# Altium schematic sheet Sheet6.SchDoc
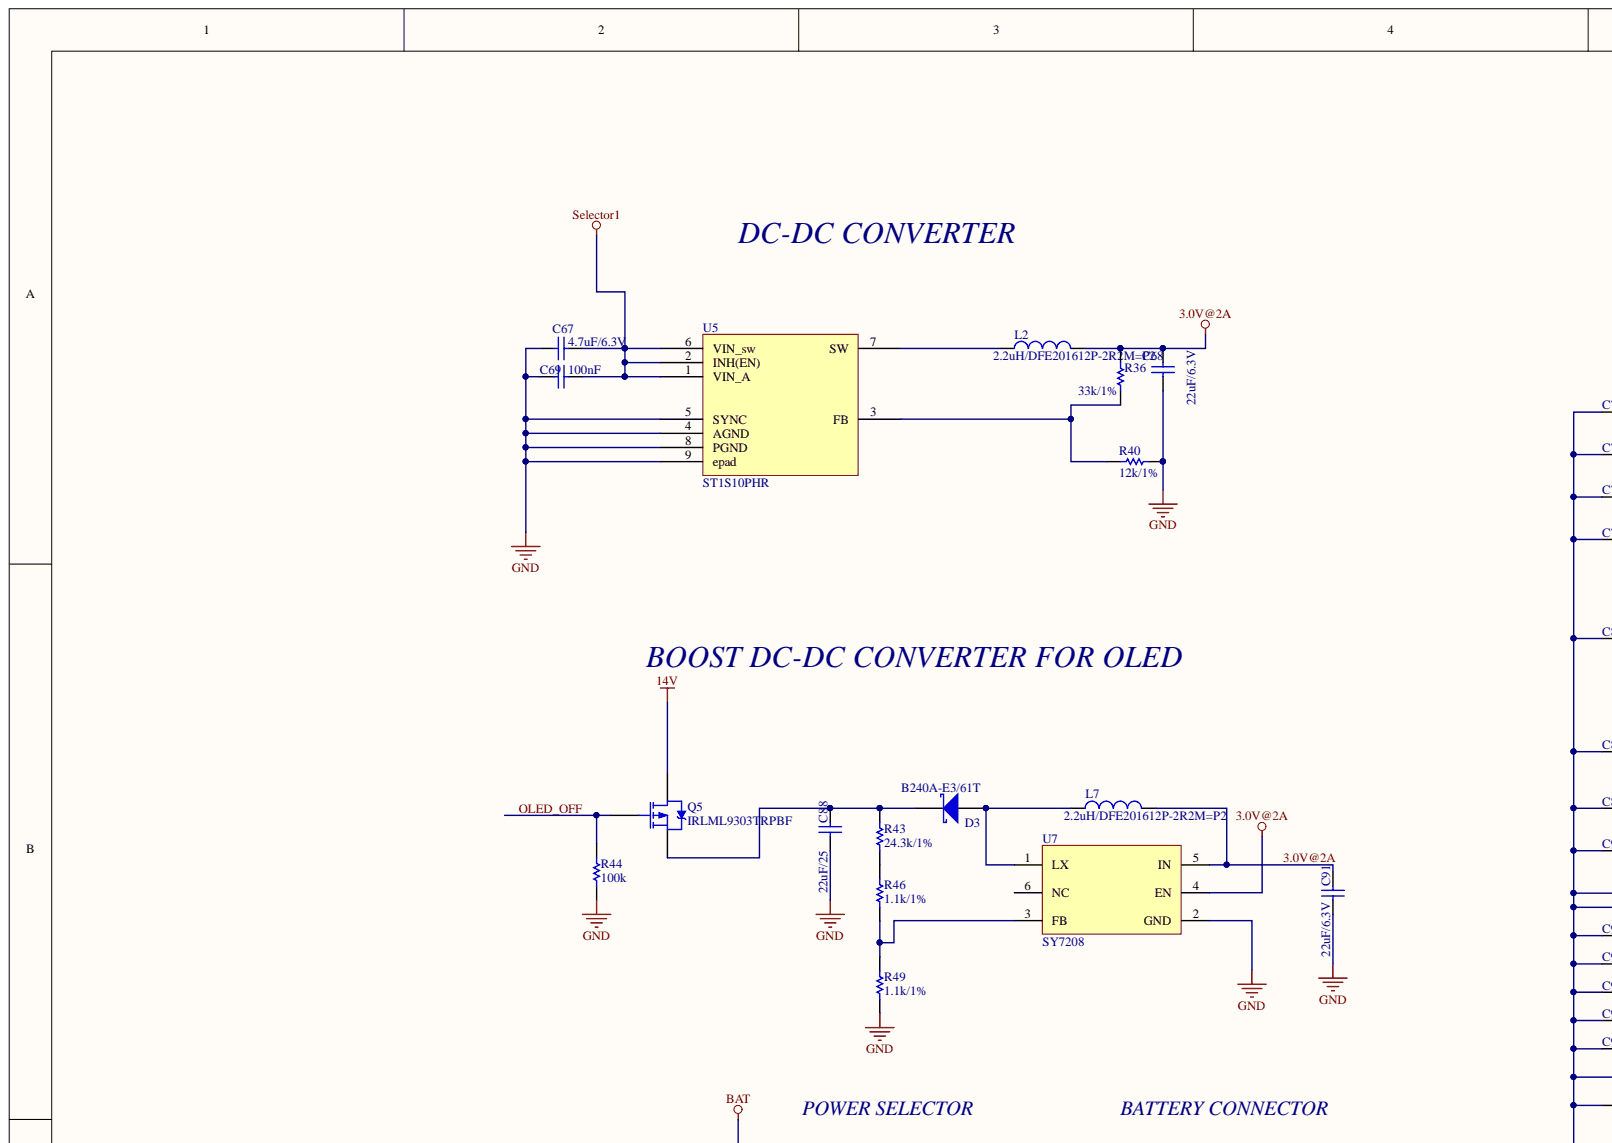
[diagram p0: Sheet6.SchDoc, top left]
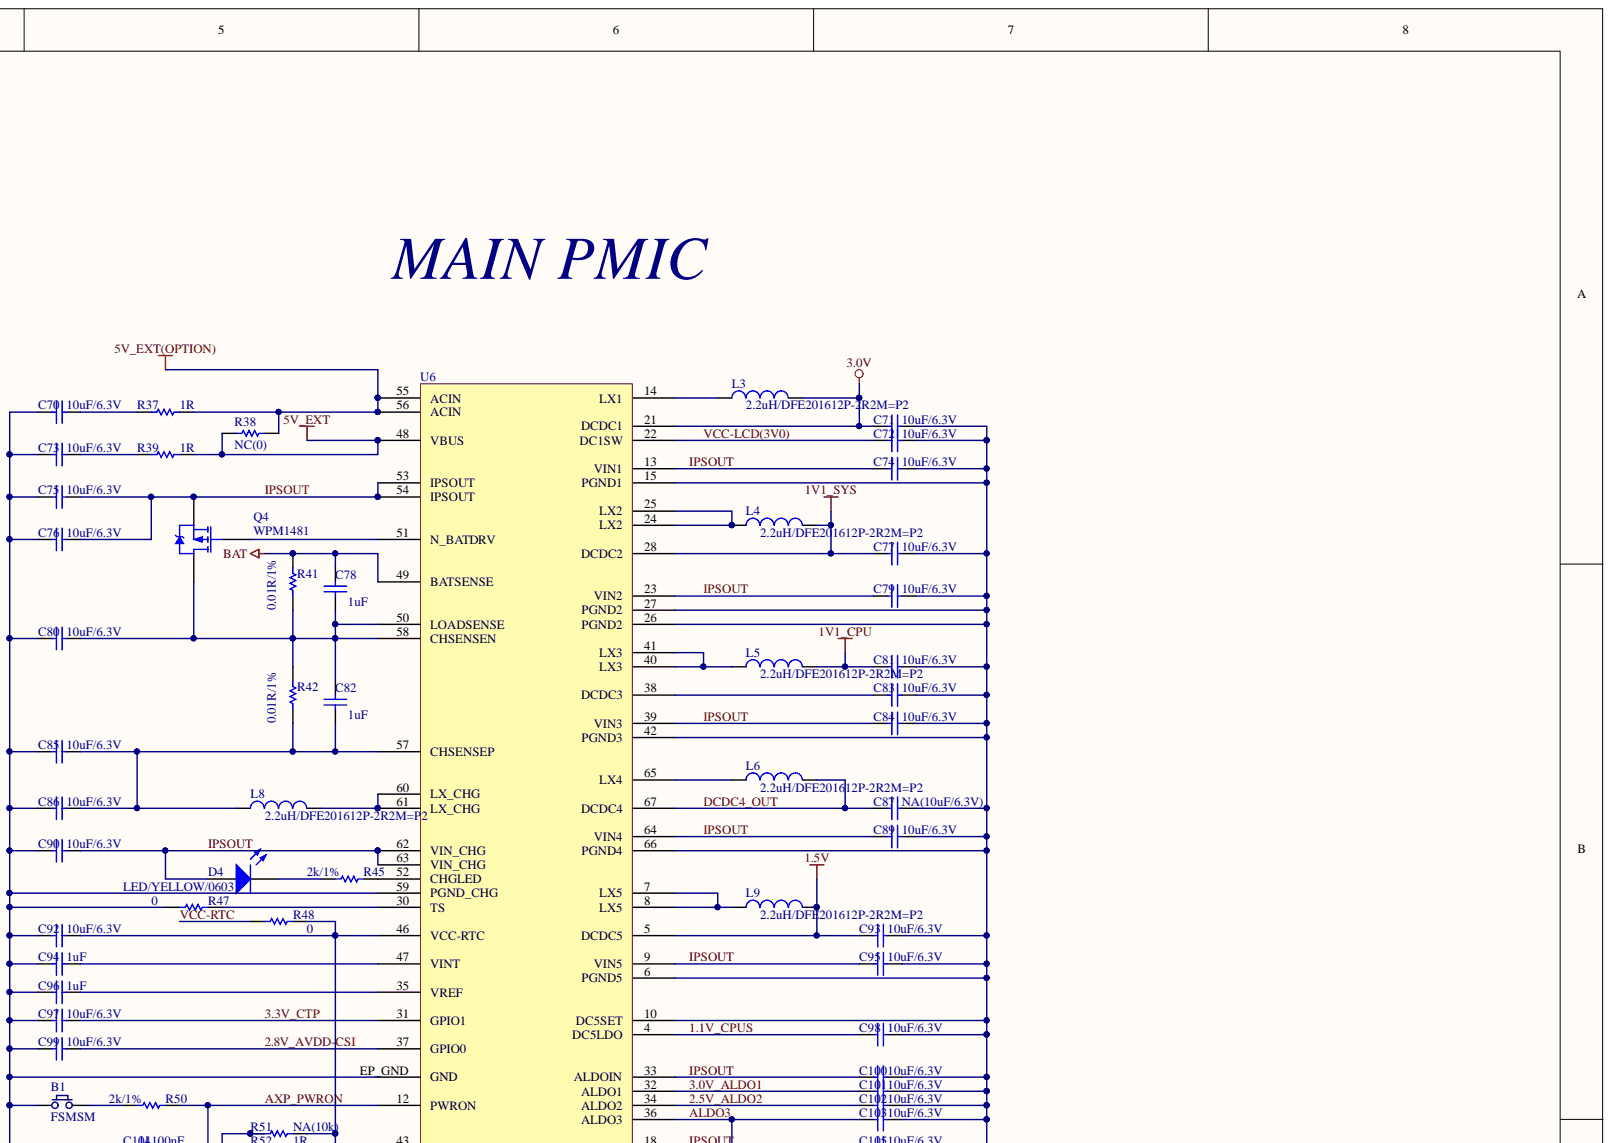
[diagram p1: Sheet6.SchDoc, top right]
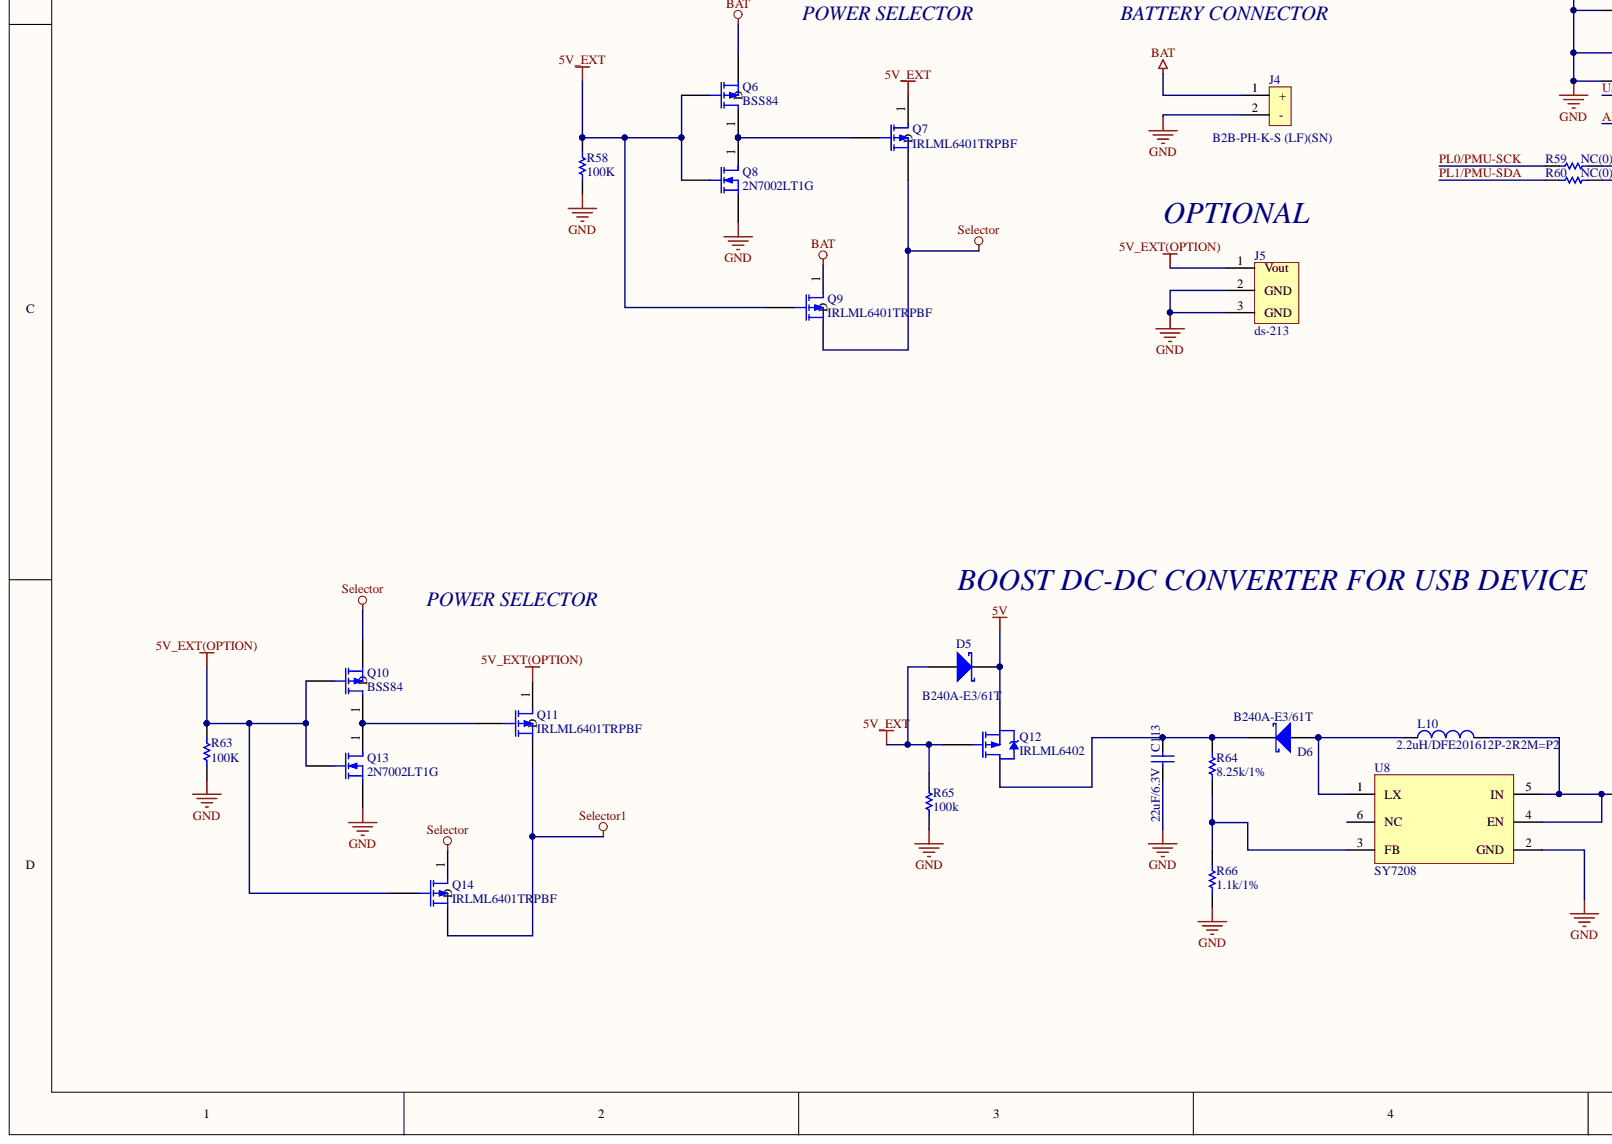
[diagram p2: Sheet6.SchDoc, bottom left]
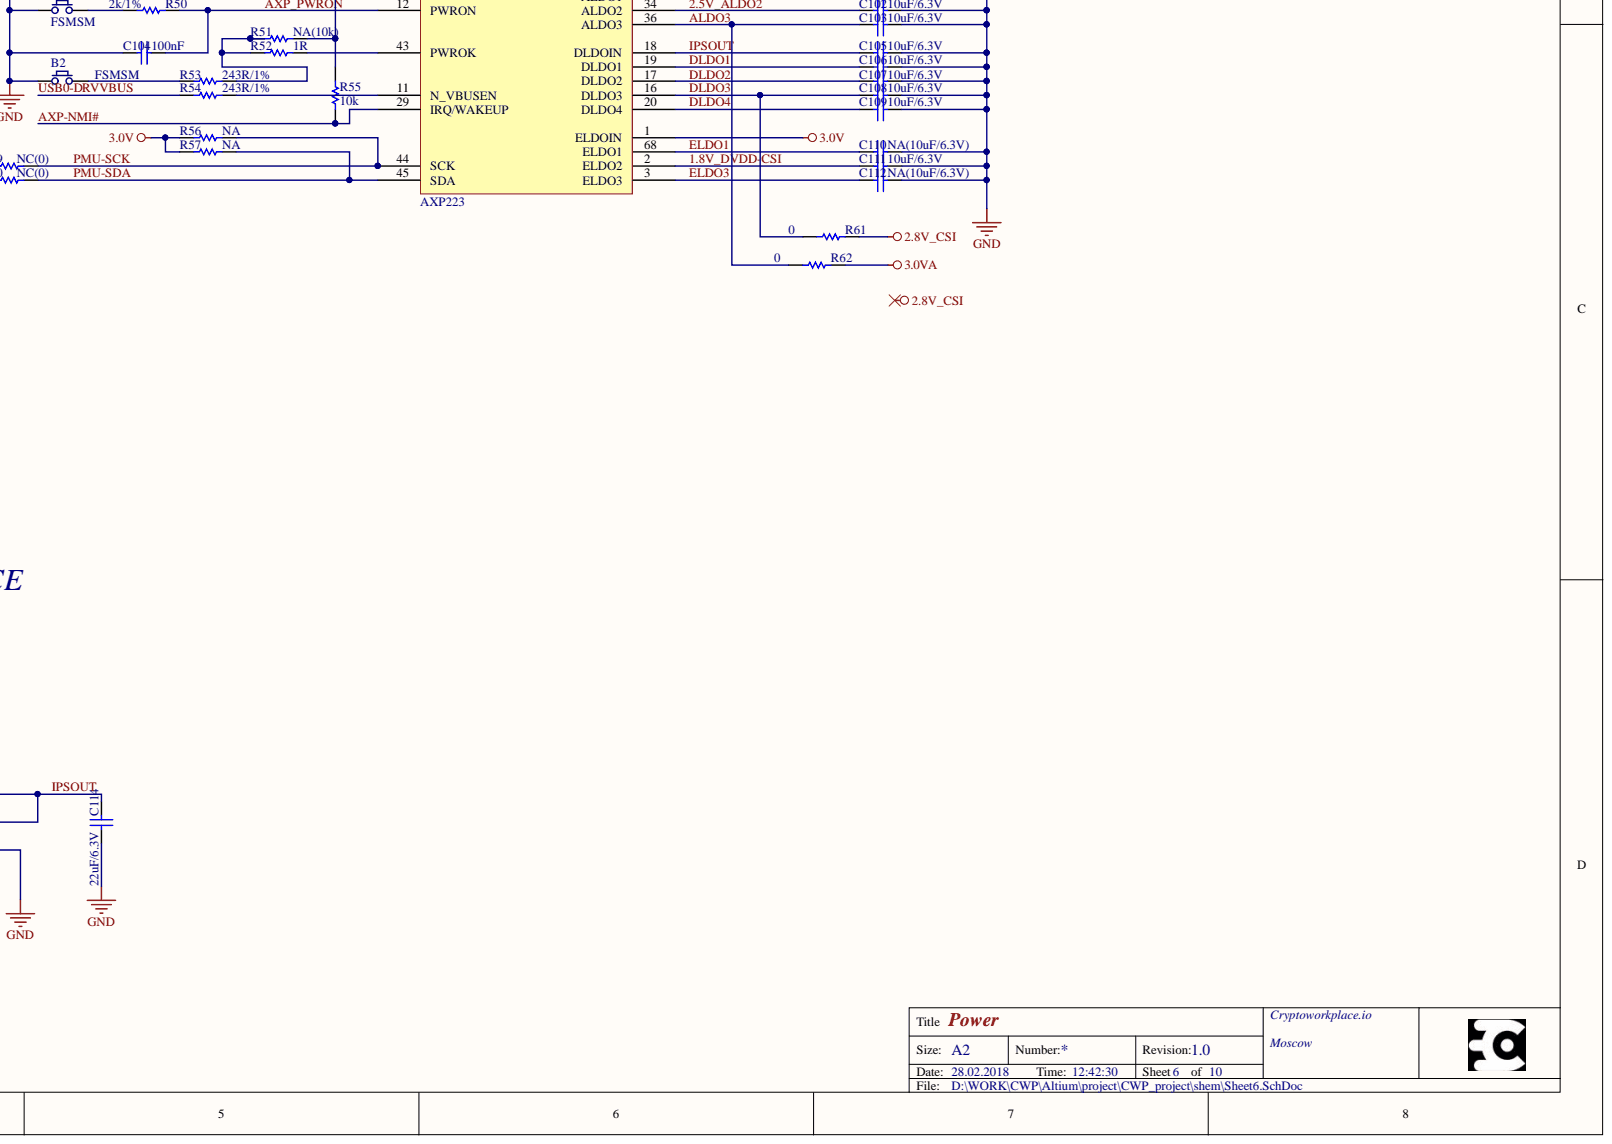
[diagram p3: Sheet6.SchDoc, bottom right]

## Parts
B1  FSMSM  [FSMSM]
B2  FSMSM  [FSMSM]
C67  value 4.7uF/6.3V  [0603(CAPC1608X09N)]  (hidden comment: Cap)
C68  value 22uF/6.3V  [0603(CAPC1608X09N)]  (hidden comment: Cap)
C69  value 100nF  [0402(CAPC1005X06N)]  (hidden comment: 0402)
C70  value 10uF/6.3V  [0603(CAPC1608X09N)]  (hidden comment: Cap)
C71  value 10uF/6.3V  [0603(CAPC1608X09N)]  (hidden comment: Cap)
C72  value 10uF/6.3V  [0603(CAPC1608X09N)]  (hidden comment: Cap)
C73  value 10uF/6.3V  [0603(CAPC1608X09N)]  (hidden comment: Cap)
C74  value 10uF/6.3V  [0603(CAPC1608X09N)]  (hidden comment: Cap)
C75  value 10uF/6.3V  [0603(CAPC1608X09N)]  (hidden comment: Cap)
C76  value 10uF/6.3V  [0603(CAPC1608X09N)]  (hidden comment: Cap)
C77  value 10uF/6.3V  [0603(CAPC1608X09N)]  (hidden comment: Cap)
C78  value 1uF  [0603(CAPC1608X09N)]  (hidden comment: Cap)
C79  value 10uF/6.3V  [0603(CAPC1608X09N)]  (hidden comment: Cap)
C80  value 10uF/6.3V  [0603(CAPC1608X09N)]  (hidden comment: Cap)
C81  value 10uF/6.3V  [0603(CAPC1608X09N)]  (hidden comment: Cap)
C82  value 1uF  [0603(CAPC1608X09N)]  (hidden comment: Cap)
C83  value 10uF/6.3V  [0603(CAPC1608X09N)]  (hidden comment: Cap)
C84  value 10uF/6.3V  [0603(CAPC1608X09N)]  (hidden comment: Cap)
C85  value 10uF/6.3V  [0603(CAPC1608X09N)]  (hidden comment: Cap)
C86  value 10uF/6.3V  [0603(CAPC1608X09N)]  (hidden comment: Cap)
C87  value NA(10uF/6.3V)  [0603(CAPC1608X09N)]  (hidden comment: Cap)
C88  value 22uF/25  [0805(CAPC2012X13N)]  (hidden comment: Cap)
C89  value 10uF/6.3V  [0603(CAPC1608X09N)]  (hidden comment: Cap)
C90  value 10uF/6.3V  [0603(CAPC1608X09N)]  (hidden comment: Cap)
C91  value 22uF/6.3V  [0603(CAPC1608X09N)]  (hidden comment: Cap)
C92  value 10uF/6.3V  [0603(CAPC1608X09N)]  (hidden comment: Cap)
C93  value 10uF/6.3V  [0603(CAPC1608X09N)]  (hidden comment: Cap)
C94  value 1uF  [0603(CAPC1608X09N)]  (hidden comment: Cap)
C95  value 10uF/6.3V  [0603(CAPC1608X09N)]  (hidden comment: Cap)
C96  value 1uF  [0603(CAPC1608X09N)]  (hidden comment: Cap)
C97  value 10uF/6.3V  [0603(CAPC1608X09N)]  (hidden comment: Cap)
C98  value 10uF/6.3V  [0603(CAPC1608X09N)]  (hidden comment: Cap)
C99  value 10uF/6.3V  [0603(CAPC1608X09N)]  (hidden comment: Cap)
C100  value 10uF/6.3V  [0603(CAPC1608X09N)]  (hidden comment: Cap)
C101  value 10uF/6.3V  [0603(CAPC1608X09N)]  (hidden comment: Cap)
C102  value 10uF/6.3V  [0603(CAPC1608X09N)]  (hidden comment: Cap)
C103  value 10uF/6.3V  [0603(CAPC1608X09N)]  (hidden comment: Cap)
C104  value 100nF  [0603(CAPC1608X09N)]  (hidden comment: Cap)
C105  value 10uF/6.3V  [0603(CAPC1608X09N)]  (hidden comment: Cap)
C106  value 10uF/6.3V  [0603(CAPC1608X09N)]  (hidden comment: Cap)
C107  value 10uF/6.3V  [0603(CAPC1608X09N)]  (hidden comment: Cap)
C108  value 10uF/6.3V  [0603(CAPC1608X09N)]  (hidden comment: Cap)
C109  value 10uF/6.3V  [0603(CAPC1608X09N)]  (hidden comment: Cap)
C110  value NA(10uF/6.3V)  [0603(CAPC1608X09N)]  (hidden comment: Cap)
C111  value 10uF/6.3V  [0603(CAPC1608X09N)]  (hidden comment: Cap)
C112  value NA(10uF/6.3V)  [0603(CAPC1608X09N)]  (hidden comment: Cap)
C113  value 22uF/6.3V  [0603(CAPC1608X09N)]  (hidden comment: Cap)
C114  value 22uF/6.3V  [0603(CAPC1608X09N)]  (hidden comment: Cap)
D3  B240A-E3/61T  [DO-214AC]
D4  LED/YELLOW/0603  [LED0603]
D5  B240A-E3/61T  [DO-214AC]
D6  B240A-E3/61T  [DO-214AC]
J4  B2B-PH-K-S (LF)(SN)  [B2B-PH-K-S (LF)(SN)]
J5  ds-213  [DS-213]
L2  value 2.2uH/DFE201612P-2R2M=P2  [0805(INDC2012X0N)]  (hidden comment: SWPA4018S1R5NT(1.5uH/3A/DCR<0.1R))
L3  value 2.2uH/DFE201612P-2R2M=P2  [0805(INDC2012X0N)]  (hidden comment: SWPA4018S1R5NT(1.5uH/3A/DCR<0.1R))
L4  value 2.2uH/DFE201612P-2R2M=P2  [0805(INDC2012X0N)]  (hidden comment: SWPA4018S1R5NT(1.5uH/3A/DCR<0.1R))
L5  value 2.2uH/DFE201612P-2R2M=P2  [0805(INDC2012X0N)]  (hidden comment: SWPA4018S1R5NT(1.5uH/3A/DCR<0.1R))
L6  value 2.2uH/DFE201612P-2R2M=P2  [0805(INDC2012X0N)]  (hidden comment: SWPA4018S1R5NT(1.5uH/3A/DCR<0.1R))
L7  value 2.2uH/DFE201612P-2R2M=P2  [0805(INDC2012X0N)]  (hidden comment: SWPA4018S1R5NT(1.5uH/3A/DCR<0.1R))
L8  value 2.2uH/DFE201612P-2R2M=P2  [0805(INDC2012X0N)]  (hidden comment: SWPA4018S1R5NT(1.5uH/3A/DCR<0.1R))
L9  value 2.2uH/DFE201612P-2R2M=P2  [0805(INDC2012X0N)]  (hidden comment: SWPA4018S1R5NT(1.5uH/3A/DCR<0.1R))
L10  value 2.2uH/DFE201612P-2R2M=P2  [0805(INDC2012X0N)]  (hidden comment: SWPA4018S1R5NT(1.5uH/3A/DCR<0.1R))
Q4  WPM1481  [DFN 2x2-6L]
Q5  IRLML9303TRPBF  [IRLML6402]
Q6  BSS84  [BSS84]
Q7  IRLML6401TRPBF  [BSS84]
Q8  2N7002LT1G  [BSS84]
Q9  IRLML6401TRPBF  [BSS84]
Q10  BSS84  [BSS84]
Q11  IRLML6401TRPBF  [BSS84]
Q12  IRLML6402  [IRLML6402]
Q13  2N7002LT1G  [BSS84]
Q14  IRLML6401TRPBF  [BSS84]
R36  value 33k/1%  [0402(RESC1005X04N)]  (hidden comment: Res1)
R37  value 1R  [0402(RESC1005X04N)]  (hidden comment: Res1)
R38  value NC(0)  [0402(RESC1005X04N)]  (hidden comment: Res1)
R39  value 1R  [0402(RESC1005X04N)]  (hidden comment: Res1)
R40  value 12k/1%  [0402(RESC1005X04N)]  (hidden comment: Res1)
R41  value 0.01R/1%  [0402(RESC1005X04N)]  (hidden comment: Res1)
R42  value 0.01R/1%  [0402(RESC1005X04N)]  (hidden comment: Res1)
R43  value 24.3k/1%  [0402(RESC1005X04N)]  (hidden comment: Res1)
R44  value 100k  [0402(RESC1005X04N)]  (hidden comment: Res1)
R45  value 2k/1%  [0402(RESC1005X04N)]  (hidden comment: Res1)
R46  value 1.1k/1%  [0402(RESC1005X04N)]  (hidden comment: Res1)
R47  value 0  [0402(RESC1005X04N)]  (hidden comment: Res1)
R48  value 0  [0402(RESC1005X04N)]  (hidden comment: Res1)
R49  value 1.1k/1%  [0402(RESC1005X04N)]  (hidden comment: Res1)
R50  value 2k/1%  [0402(RESC1005X04N)]  (hidden comment: Res1)
R51  value NA(10k)  [0402(RESC1005X04N)]  (hidden comment: Res1)
R52  value 1R  [0402(RESC1005X04N)]  (hidden comment: Res1)
R53  value 243R/1%  [0402(RESC1005X04N)]  (hidden comment: Res1)
R54  value 243R/1%  [0402(RESC1005X04N)]  (hidden comment: Res1)
R55  value 10k  [0402(RESC1005X04N)]  (hidden comment: Res1)
R56  value NA  [0402(RESC1005X04N)]  (hidden comment: Res1)
R57  value NA  [0402(RESC1005X04N)]  (hidden comment: Res1)
R58  value 100K  [0402(RESC1005X04N)]
R59  value NC(0)  [0402(RESC1005X04N)]  (hidden comment: Res1)
R60  value NC(0)  [0402(RESC1005X04N)]  (hidden comment: Res1)
R61  value 0  [0402(RESC1005X04N)]  (hidden comment: Res1)
R62  value 0  [0402(RESC1005X04N)]  (hidden comment: Res1)
R63  value 100K  [0402(RESC1005X04N)]
R64  value 8.25k/1%  [0402(RESC1005X04N)]  (hidden comment: Res1)
R65  value 100k  [0402(RESC1005X04N)]  (hidden comment: Res1)
R66  value 1.1k/1%  [0402(RESC1005X04N)]  (hidden comment: Res1)
U5  ST1S10PHR  [SOIC127P600X170_HS-9N]
U6  AXP223  [QFN40P800X800X80_HS-69N]
U7  SY7208  [SOT23-6]
U8  SY7208  [SOT23-6]

## Nets
1.5V: C93.1 L9.2 U6.5(DCDC5)
1V1_CPU: C81.1 L5.2
1V1_SYS: C77.1 L4.2 U6.28(DCDC2)
2.8V_CSI: R61.1
3.0V: C71.1 L3.2 R56.2 R57.2 U6.1(ELDOIN) U6.21(DCDC1)
3.0VA: R62.1
5V: D5.2 Q12.3
5V_EXT: D5.1 Q6.2 Q7.1 Q8.2 Q9.2 Q12.1 R38.2 R39.1 R58.2 R65.1 U6.48(VBUS)
5V_EXT(OPTION): J5.1 Q10.2 Q11.1 Q13.2 Q14.2 R37.1 R38.1 R63.2 U6.55(ACIN) U6.56(ACIN)
14V: Q5.2
BAT: C78.2 J4.1 Q6.3 Q9.1 R41.1 U6.49(BATSENSE)
GND: B1.1 B2.1 C67.1 C68.2 C69.2 C70.1 C71.2 C72.2 C73.1 C74.2 C75.1 C76.1 C77.2 C79.2 C80.1 C81.2 C83.2 C84.2 C85.1 C86.1 C87.2 C88.1 C89.2 C90.1 C91.1 C92.1 C93.2 C94.1 C95.2 C96.1 C97.1 C98.2 C99.1 C100.2 C101.2 C102.2 C103.2 C104.2 C105.2 C106.2 C107.2 C108.2 C109.2 C110.2 C111.2 C112.2 C113.1 C114.1 J4.2 J5.2 J5.3 Q8.3 Q13.3 R40.2 R44.2 R47.2 R49.2 R58.1 R63.1 R65.2 R66.2 U5.4(AGND) U5.5(SYNC) U5.8(PGND) U5.9(epad) U6.6(PGND5) U6.10(DC5SET) U6.15(PGND1) U6.26(PGND2) U6.27(PGND2) U6.42(PGND3) U6.59(PGND_CHG) U6.66(PGND4) U6.EP_GND(GND) U7.2(GND) U8.2(GND)
Selector: Q7.3 Q9.3 Q10.3 Q14.1
Selector1: C67.2 C69.1 Q11.3 Q14.3 U5.1(VIN_A) U5.2(INH(EN)) U5.6(VIN_sw)
1.1V_CPUS: C98.1 U6.4(DC5LDO)
1.8V_DVDD-CSI: C111.1 U6.2(ELDO2)
2.5V_ALDO2: C102.1 U6.34(ALDO2)
2.8V_AVDD-CSI: C99.2 U6.37(GPIO0)
3.0V@2A: C68.1 C91.2 L2.2 L7.2 R36.1 U7.4(EN) U7.5(IN)
3.0V_ALDO1: C101.1 U6.32(ALDO1)
3.3V_CTP: C97.2 U6.31(GPIO1)
ALDO3: C103.1 R62.2 U6.36(ALDO3)
AXP-NMI#: R55.2 U6.29(IRQ/WAKEUP)
AXP_PWRON: C104.1 R50.1 U6.12(PWRON)
DCDC4_OUT: C87.1 L6.2 U6.67(DCDC4)
DLDO1: C106.1 U6.19(DLDO1)
DLDO2: C107.1 U6.17(DLDO2)
DLDO3: C108.1 R61.2 U6.16(DLDO3)
DLDO4: C109.1 U6.20(DLDO4)
ELDO1: C110.1 U6.68(ELDO1)
ELDO3: C112.1 U6.3(ELDO3)
IPSOUT: C74.1 C75.2 C76.2 C79.1 C84.1 C89.1 C90.2 C95.1 C100.1 C105.1 C114.2 D4.1 L10.2 Q4.S U6.9(VIN5) U6.13(VIN1) U6.18(DLDOIN) U6.23(VIN2) U6.33(ALDOIN) U6.39(VIN3) U6.53(IPSOUT) U6.54(IPSOUT) U6.62(VIN_CHG) U6.63(VIN_CHG) U6.64(VIN4) U8.4(EN) U8.5(IN)
OLED_OFF: Q5.1 R44.1
PL0/PMU-SCK: R59.1
PL1/PMU-SDA: R60.1
PMU-SCK: R56.1 R59.2 U6.44(SCK)
PMU-SDA: R57.1 R60.2 U6.45(SDA)
USB0-DRVVBUS: R54.1
VCC-LCD(3V0): C72.1 U6.22(DC1SW)
VCC-RTC: R48.2
NetB1_2: B1.2 R50.2
NetB2_2: B2.2 R53.1
NetC70_2: C70.2 R37.2
NetC73_2: C73.2 R39.2
NetC78_1: C78.1 C80.2 C82.2 Q4.D R41.2 R42.1 U6.50(LOADSENSE) U6.58(CHSENSEN)
NetC82_1: C82.1 C85.2 C86.2 L8.1 R42.2 U6.57(CHSENSEP)
NetC83_1: C83.1 U6.38(DCDC3)
NetC88_2: C88.2 D3.2 Q5.3 R43.1
NetC92_2: C92.2 R48.1 R51.1 R55.1 U6.46(VCC-RTC)
NetC94_2: C94.2 U6.47(VINT)
NetC96_2: C96.2 U6.35(VREF)
NetC113_2: C113.2 D6.2 Q12.2 R64.1
NetD3_1: D3.1 L7.1 U7.1(LX)
NetD4_2: D4.2 R45.2
NetD6_1: D6.1 L10.1 U8.1(LX)
NetL2_1: L2.1 U5.7(SW)
NetL3_1: L3.1 U6.14(LX1)
NetL4_1: L4.1 U6.24(LX2) U6.25(LX2)
NetL5_1: L5.1 U6.40(LX3) U6.41(LX3)
NetL6_1: L6.1 U6.65(LX4)
NetL8_2: L8.2 U6.60(LX_CHG) U6.61(LX_CHG)
NetL9_1: L9.1 U6.7(LX5) U6.8(LX5)
NetQ4_G: Q4.G U6.51(N_BATDRV)
NetQ6_1: Q6.1 Q7.2 Q8.1
NetQ10_1: Q10.1 Q11.2 Q13.1
NetR36_2: R36.2 R40.1 U5.3(FB)
NetR43_2: R43.2 R46.1
NetR45_1: R45.1 U6.52(CHGLED)
NetR46_2: R46.2 R49.1 U7.3(FB)
NetR47_1: R47.1 U6.30(TS)
NetR51_2: R51.2 R52.2 R53.2
NetR52_1: R52.1 U6.43(PWROK)
NetR54_2: R54.2 U6.11(N_VBUSEN)
NetR64_2: R64.2 R66.1 U8.3(FB)

Not connected: U7 6(NC); U8 6(NC)

## Library data of the parts
B1 B2 = DTSM-32N: тактовая кнопка; Component Kind: Standard; Library Name: Button.SchLib
C67-C114 = Cap: Capacitor; Component Kind: Standard; LatestRevisionDate: 17-Jul-2002; LatestRevisionNote: Re-released for DXP Platform.; Library Name: Miscellaneous Devices.IntLib; PackageDescription: Radial Cap, Thru-Hole; 2 Leads; 0.3 in Pin Spacing; PackageReference: RAD-0.3; Published: 8-Jun-2000; Signal Integrity: Cap; Simulation: CAP; Publisher: Altium Limited
D3 D5 D6 = D Schottky: Schottky Diode; Code_JEDEC: DO-214-AA; Component Kind: Standard; LatestRevisionDate: 17-Jul-2002; LatestRevisionNote: Re-released for DXP Platform.; Library Name: Miscellaneous Devices.IntLib; PackageDescription: DO-214-AA/SMB; 2 C-Bend Leads; Body 5.3 x 3.6 mm, inc. leads (LxW); PackageReference: SMB; Published: 8-Jun-2000; Signal Integrity: D Schottky; Simulation: SKYDIODE; Publisher: Altium Limited
D4 = LED0: Typical INFRARED GaAs LED; Component Kind: Standard; LatestRevisionDate: 08-Jul-2005; LatestRevisionNote: Mech Layer 1 removed.; Library Name: Miscellaneous Devices.IntLib; PackageDescription: LED; 2 Leads; PackageReference: LED-0; Published: 8-Jun-2000; Simulation: LED0; Publisher: Altium Limited
J5 = ds-313: Component Kind: Standard; Library Name: powermanagement.SchLib
L2-L10 = Inductor: Code_IEC: 1005; Code_JEITA: 0402; Component Kind: Standard; Description: Inductor; LatestRevisionDate: 29-May-2009; LatestRevisionNote: IPC-7351 Footprint Added.; Library Name: Miscellaneous Devices.IntLib; PackageDescription: Chip Inductor; PackageReference: 0402-A; Published: 8-Jun-2000; Signal Integrity: Inductor; Simulation: INDUCTOR; Publisher: Altium Limited
Q4 Q5 Q12 = PMOS-2: P-Channel Power MOSFET; Note: Pin 4 not drawn and electrically connected to Pin 2.; Component Kind: Standard; ComponentLink1Description: Manufacturer Link; ComponentLink1URL: http://www.irf.com/; DatasheetVersion: PD-9.390D; LatestRevisionDate: 17-Jul-2002; LatestRevisionNote: Re-released for DXP Platform.; Library Name: Miscellaneous Devices.IntLib; PackageDescription: TO, Thru-Hole, Vertical, Heatsink Mounted; 3 In-Line Leads; Pitch 2.54 mm; PackageReference: TO-220AB; PackageVersion: Feb-1997; Published: 8-Jun-2000; Simulation: IRF9510; Publisher: Altium Limited
Q6 Q7 Q9-Q11 Q14 = MOSFET-P: P-Channel MOSFET; Component Kind: Standard; LatestRevisionDate: 17-Jul-2002; LatestRevisionNote: Re-released for DXP Platform.; Library Name: Miscellaneous Devices.IntLib; PackageDescription: TO, Flat Index; 3 In-Line, Axial Leads; Body Dia. 4.6mm; Lead Dia. 0.5 mm (max); PackageReference: E3; PackageVersion: 1995/1996; Published: 8-Jun-2000; Simulation: PMOS; Publisher: Altium Limited
Q8 Q13 = MOSFET-N: N-Channel MOSFET; Component Kind: Standard; LatestRevisionDate: 17-Jul-2002; LatestRevisionNote: Re-released for DXP Platform.; Library Name: Miscellaneous Devices.IntLib; PackageDescription: TO, Flat Index; 3 In-Line, Axial Leads; Body Dia. 4.6mm; Lead Dia. 0.5 mm (max); PackageReference: E3; PackageVersion: 1995/1996; Published: 8-Jun-2000; Simulation: NMOS; Publisher: Altium Limited
R36-R66 = Res1: Resistor; Component Kind: Standard; LatestRevisionDate: 17-Jul-2002; LatestRevisionNote: Re-released for DXP Platform.; Library Name: Miscellaneous Devices.IntLib; PackageDescription: Axial Device, Thru-Hole; 2 Leads; 0.3 in Pin Spacing; PackageReference: AXIAL-0.3; Published: 8-Jun-2000; Signal Integrity: Res; Simulation: RESISTOR; Publisher: Altium Limited
U6 = AXP223: PMIC; Component Kind: Standard; Library Name: Xpowers.SchLib
U7 U8 = SY7208: High Efficiency 1MHz, 2A Step Up Regulator; Component Kind: Standard; Library Name: SILERGY.SchLib
J4 = B2B-PH-K-S (LF)(SN): Component Kind: Standard; Library Name: BAT.SchLib
U5 = ST1S10PHR: Component Kind: Standard; Description: ST1S10PHR; Library Name: STM8.SchLib
